# Altium schematic sheet CuC_PSU.SchDoc
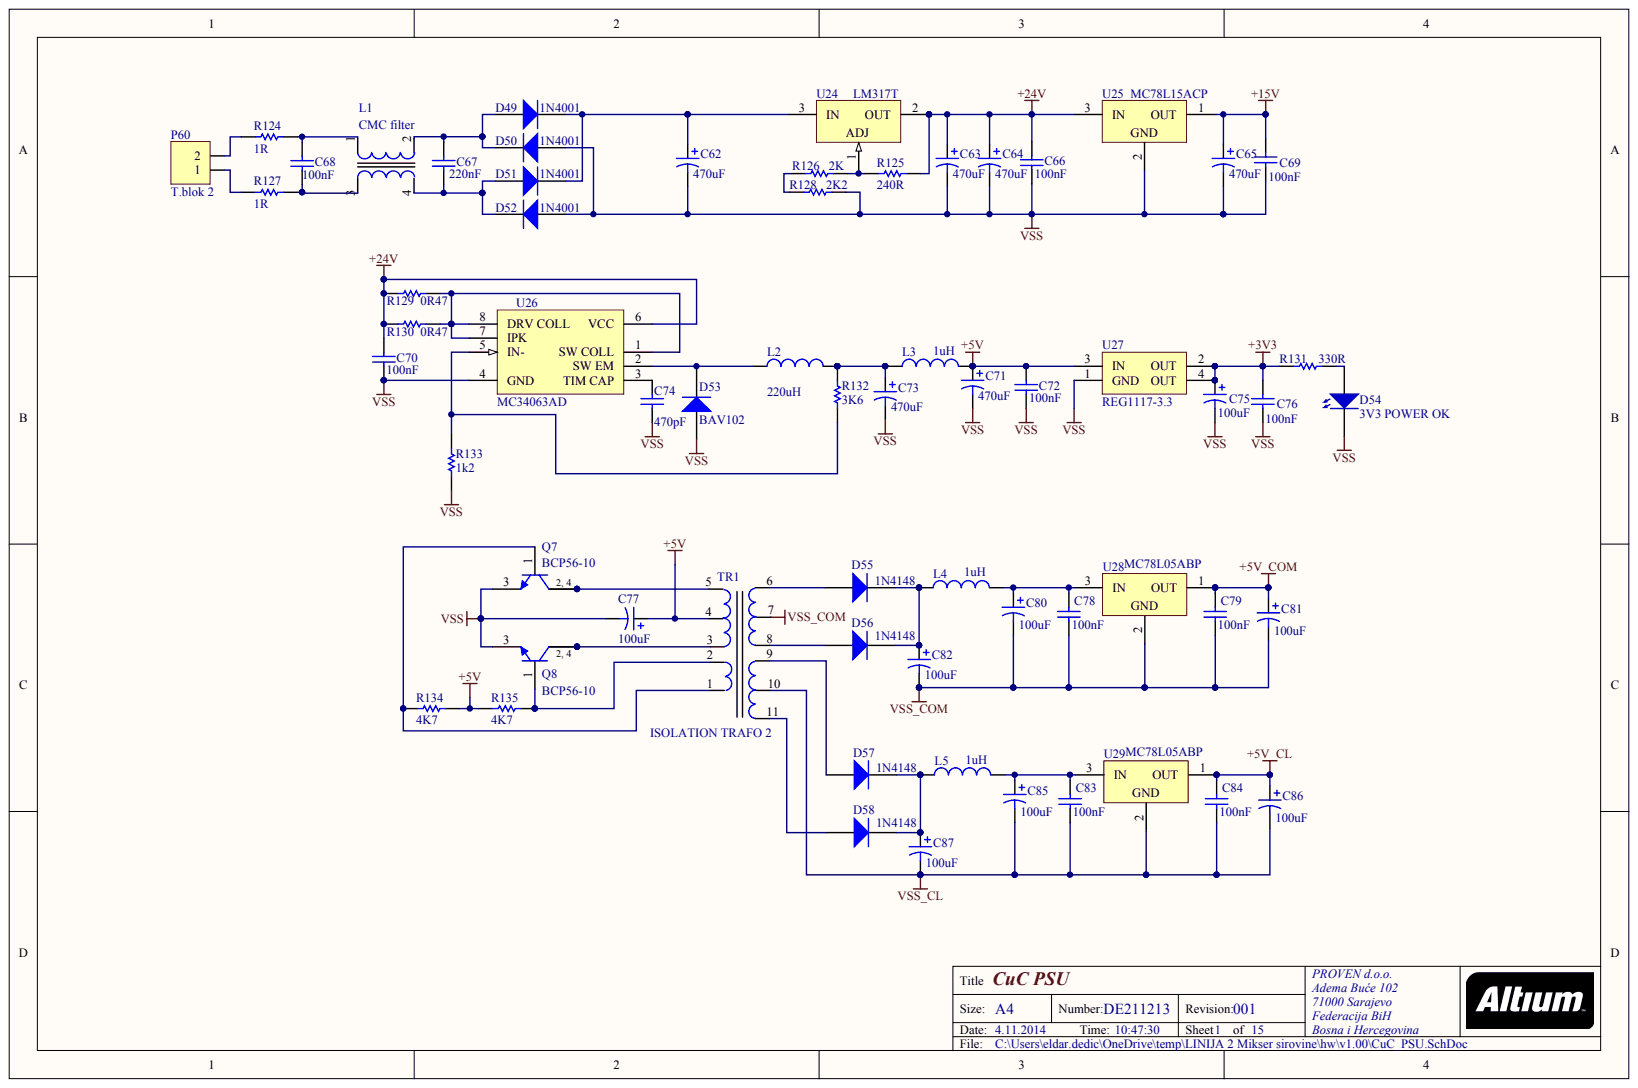
[diagram p0: CuC_PSU.SchDoc]

## Parts
C62  470uF
C63  470uF
C64  470uF
C65  470uF
C66  value 100nF
C67  220nF
C68  100nF
C69  value 100nF
C70  value 100nF
C71  470uF
C72  value 100nF
C73  470uF
C74  value 470pF
C75  100uF
C76  value 100nF
C77  100uF
C78  value 100nF
C79  value 100nF
C80  100uF
C81  100uF
C82  100uF
C83  value 100nF
C84  value 100nF
C85  100uF
C86  100uF
C87  100uF
D49  1N4001
D50  1N4001
D51  1N4001
D52  1N4001
D53  BAV102
D54  3V3 POWER OK
D55  1N4148
D56  1N4148
D57  1N4148
D58  1N4148
L1  CMC filter
L2  value 220uH
L3  value 1uH
L4  value 1uH
L5  value 1uH
P60  T.blok 2
Q7  BCP56-10
Q8  BCP56-10
R124  1R
R125  240R
R126  2K
R127  1R
R128  2K2
R129  0R47
R130  0R47
R131  330R
R132  3K6
R133  1k2
R134  4K7
R135  4K7
TR1  ISOLATION TRAFO 2
U24  LM317T
U25  MC78L15ACP
U26  MC34063AD
U27  REG1117-3.3
U28  MC78L05ABP
U29  MC78L05ABP

## Nets
+3V3: C75.1 C76.2 R131.1 U27.2(OUT) U27.4(OUT)
+5V: C71.1 C72.2 C77.1 L3.2 R134.1 R135.2 TR1.4 U27.3(IN)
+5V_CL: C84.2 C86.1 U29.1
+5V_COM: C79.2 C81.1 U28.1
+15V: C65.1 C69.2 U25.1
+24V: C63.1 C64.1 C66.2 C70.2 R125.1 R129.1 R130.1 U24.2 U25.3 U26.6(VCC)
VSS: C62.2 C63.2 C64.2 C65.2 C66.1 C69.1 C70.1 C71.2 C72.1 C73.2 C74.1 C75.2 C76.1 C77.2 D50.1 D52.1 D53.1 D54.1 Q7.3 Q8.3 R128.1 R133.1 U25.2 U26.4(GND) U27.1(GND)
VSS_CL: C83.1 C84.1 C85.2 C86.2 C87.2 TR1.10 U29.2
VSS_COM: C78.1 C79.1 C80.2 C81.2 C82.2 TR1.7 U28.2
NetC62_1: C62.1 D49.2 D51.2 U24.3
NetC67_1: C67.1 D51.1 D52.2 L1.4
NetC67_2: C67.2 D49.1 D50.2 L1.2
NetC68_1: C68.1 L1.3 R127.1
NetC68_2: C68.2 L1.1 R124.1
NetC73_1: C73.1 L2.2 L3.1 R132.2
NetC74_2: C74.2 U26.3(TIM_CAP)
NetC78_2: C78.2 C80.1 L4.2 U28.3
NetC82_1: C82.1 D55.2 D56.2 L4.1
NetC83_2: C83.2 C85.1 L5.2 U29.3
NetC87_1: C87.1 D57.2 D58.2 L5.1
NetD53_2: D53.2 L2.1 U26.2(SW_EM)
NetD54_2: D54.2 R131.2
NetD55_1: D55.1 TR1.6
NetD56_1: D56.1 TR1.8
NetD57_1: D57.1 TR1.9
NetD58_1: D58.1 TR1.11
NetP60_1: P60.1 R127.2
NetP60_2: P60.2 R124.2
NetQ7_1: Q7.1 R134.2 TR1.1
NetQ7_2: Q7.2 Q7.4 TR1.5
NetQ8_1: Q8.1 R135.1 TR1.2
NetQ8_2: Q8.2 Q8.4 TR1.3
NetR125_2: R125.2 R126.2 U24.1
NetR126_1: R126.1 R128.2
NetR129_2: R129.2 R130.2 U26.1(SW_COLL) U26.7(IPK) U26.8(DRV_COLL)
NetR132_1: R132.1 R133.2 U26.5(IN-)

## Library data of the parts
C62-C65 C71 C73 C75 C77 C80-C82 C85-C87 = (no symbol name): Note: Refer to Manufacturer's Data Sheets for other lead formations; DrillSize: Nominal, Rounded To The Nearest 0.1mm; Published: 19-Mar-2004; DatasheetDocument: 20-Feb-2001; ComponentLink1Description: Manufacturer Link; ComponentLink1URL: http://www.vishay.com/; ComponentLink2Description: Datasheet; ComponentLink2URL: http://www.vishay.com/docs/25014/eka.pdf; Publisher: Altium Limited
  C62-C65 C71 C73: PackageReference: CAPPR5-10X16; Value: 470uF
  C75 C77 C80-C82 C85-C87: PackageReference: CAPPR2-5X11; Value: 100uF
C67 C68 = (no symbol name): Value: 68nF; Published: 17-Dec-2003; LatestRevisionDate: 19-Mar-2004; LatestRevisionNote: PCB Footprint - Drill hole diameter reduced.; DatasheetDocument: 2-Jan-2002; PackageDocument: 2-Jan-2002; PackageReference: VP60-3.6; ComponentLink1Description: Manufacturer Link; ComponentLink1URL: http://www.vishay.com/; Publisher: Altium Limited
C74 = (no symbol name): Note: Footprint corresponds to dimensions for bulk cassette packaging. This case size has tightened tolerances.; Published: 17-Jul-2002; LatestRevisionDate: 19-Mar-2004; LatestRevisionNote: Silkscreen size reduced.; DatasheetDocument: Mar-2001; PackageDocument: Mar-2001; PackageReference: 0805; Code_JEITA: 0805; Code_IPC: 2012[0805]; ComponentLink1Description: Manufacturer Link; ComponentLink1URL: http://www.kemet.com/; ComponentLink2Description: Datasheet; ComponentLink2URL: http://www.kemet.com/kemet/web/homepage/kechome.nsf/vapubfilesname/F3102TA.pdf/$file/F3102TA.pdf; Publisher: Altium Limited
L2-L5 = (no symbol name): PCB_Layout: Complies with manufacturer's recommendation.; Published: 26-Sep-2008; DatasheetVersion: Oct-2007; PackageVersion: Oct-2007; PackageReference: PCMC063T; PackageDescription: SM Power Choke Coil; 2 Leads; Body 7.3 x 6.6 x 3.0 mm (LxWxH); ComponentLink1Description: Manufacturer Link; ComponentLink1URL: http://www.susumu.co.jp/; ComponentLink2Description: Datasheet; ComponentLink2URL: http://www.susumu.co.jp/english/pdf/products-j07-01.pdf; Publisher: Altium Limited
R125 R126 R128 R131-R135 = (no symbol name): Note: If flow soldering, adjust pad width of PCB Footprint to 0.7 - 0.8 times the width of the resistor.; Published: 17-Dec-2003; LatestRevisionDate: 19-Mar-2004; LatestRevisionNote: Silkscreen size reduced.; PackageDocument: Mar-2003; ComponentLink1Description: Manufacturer Link; ComponentLink1URL: http://www.panasonic.com/industrial/components/resistive_products.htm; ComponentLink2Description: Datasheet; Publisher: Altium Limited
  R125 R126 R128 R132-R135: PackageReference: ERA-0805; Code_IPC: 2012 [0805]; ComponentLink2URL: http://www.panasonic.com/industrial/components/pdf/AOF0000CE1.pdf
  R131: PackageReference: ERA-0603; Code_IPC: 1608 [0603]; ComponentLink2URL: http://www.panasonic.com/industrial/components/pdf/AOA0000CE8.pdf
U24 = (no symbol name): Note: Heatsink surface connected to pin 2.; Published: 17-Jul-2002; DatasheetVersion: 1988; PackageReference: 221A-04; PackageDescription: TO, Thru-Hole, Vertical, Heatsink Mounted; 3 In-Line Leads; Pitch 2.54 mm; Code_JEDEC: TO-262-AA; ComponentLink1Description: Manufacturer Link; ComponentLink1URL: http://www.onsemi.com/site/products/taxonomy/0,4438,503,00.html; ComponentLink2Description: Datasheet; ComponentLink2URL: http://www.onsemi.com/pub/Collateral/LM317-D.PDF; Publisher: Altium Limited
C66 C69 C70 C72 C76 C78 C79 C83 C84 D49-D58 L1 P60 Q7 Q8 R124 R127 R129 R130 TR1 U25-U29 = (no symbol name)
  C66 C69 C70 C72 C76 C78 C79 C83 C84: Published: 17-Jul-2002; LatestRevisionDate: 19-Mar-2004; LatestRevisionNote: Silkscreen size reduced.; DatasheetDocument: Mar-2001; PackageDocument: Mar-2001; PackageReference: 1206; Code_JEITA: 1206; Code_IPC: 3216 [1206]; ComponentLink1Description: Manufacturer Link; ComponentLink1URL: http://www.kemet.com/; ComponentLink2Description: Datasheet; ComponentLink2URL: http://www.kemet.com/kemet/web/homepage/kechome.nsf/vapubfilesname/F3102TA.pdf/$file/F3102TA.pdf
  D49-D52: Published: 8-Jun-2000; LatestRevisionDate: 17-Jul-2002; LatestRevisionNote: Re-released for DXP Platform.; DatasheetVersion: 1998; PackageVersion: Aug-1999; PackageReference: DO-41; PackageDescription: Diode, Axial; Body 5.2 x 2.7 mm (LxDia. max), Lead Dia. 0.86 mm (max); Code_JEDEC: DO-204-AL; ComponentLink1Description: Manufacturer Link; ComponentLink1URL: http://www.fairchildsemi.com/; ComponentLink2Description: Datasheet; ComponentLink2URL: http://www.fairchildsemi.com/ds/1N%2F1N4001.pdf
  D53: Published: 17-Sep-2003; LatestRevisionDate: 19-Mar-2004; LatestRevisionNote: Silkscreen size reduced.; DatasheetVersion: 17-Sep-1996; PackageVersion: Jan-2002; PackageReference: SOD80C; PackageDescription: Metal Electrode Face (MELF) Diode; Body 3.5 x 1.6 mm (LxDia); ComponentLink1Description: Manufacturer Link; ComponentLink1URL: http://www.semiconductors.philips.com/; ComponentLink2Description: Datasheet; ComponentLink2URL: http://www.semiconductors.philips.com/acrobat/datasheets/BAV100_2.pdf
  D55-D58: Published: 8-Jun-2000; LatestRevisionDate: 17-Jul-2002; LatestRevisionNote: Re-released for DXP Platform.; DatasheetVersion: 1997; PackageReference: DO-35; PackageDescription: Diode, Axial; Body 3.8 x 1.9 mm (LxDia. max), Lead Dia. 0.53 mm (max); Code_JEDEC: DO-204-AH; ComponentLink1Description: Manufacturer Link; ComponentLink1URL: http://www.fairchildsemi.com/; ComponentLink2Description: Datasheet; ComponentLink2URL: http://www.fairchildsemi.com/ds/1N%2F1N4148.pdf
  Q7 Q8: Published: 17-Sep-2003; LatestRevisionDate: 12-Dec-2006; LatestRevisionNote: IPC-7351 Footprint Added.; DatasheetVersion: 10-Oct-2001; PackageVersion: Aug-2001; PackageReference: SOT223; PackageDescription: 4-Pin SOT-223 Package 2.3 mm Pitch, 7 mm Lead Span; ComponentLink1Description: Manufacturer Link; ComponentLink1URL: http://www.semiconductors.philips.com/; ComponentLink2Description: Datasheet; ComponentLink2URL: http://www.semiconductors.philips.com/acrobat/datasheets/BC639_BCP56_BCX56_7.pdf
  R124 R127: Published: 17-Dec-2003; PackageReference: R6.35x2.3; ComponentLink1Description: Manufacturer Link; ComponentLink1URL: http://www.panasonic.com/industrial/components/resistive_products.htm; ComponentLink2Description: Datasheet; ComponentLink2URL: http://www.panasonic.com/industrial/components/pdf/AOA0000CE18.pdf
  R129 R130: Published: 17-Dec-2003; PackageReference: RD6.35x2.3; ComponentLink1Description: Manufacturer Link; ComponentLink1URL: http://www.panasonic.com/industrial/components/resistive_products.htm; ComponentLink2Description: Datasheet; ComponentLink2URL: http://www.panasonic.com/industrial/components/pdf/AOA0000CE12.pdf
  U25 U28 U29: Published: 17-Jul-2002; DatasheetVersion: 1988; PackageVersion: 1996; PackageReference: 29-04; PackageDescription: TO, Flat Index; 3 In-Line, Axial Leads; Body Dia. 4.8mm; Leads 0.48 x 0.5 mm (max); ComponentLink1Description: Manufacturer Link; ComponentLink1URL: http://www.onsemi.com/site/products/taxonomy/0,4438,503,00.html; ComponentLink2Description: Datasheet; ComponentLink2URL: http://www.onsemi.com/pub/Collateral/MC78L00A-D.PDF
  U26: Published: 15-Apr-2002; LatestRevisionDate: 13-Apr-2006; LatestRevisionNote: IPC-7351 Footprint Added.; DatasheetVersion: 1996; PackageVersion: 1998; PackageReference: 751-05; PackageDescription: 8-Pin Small Outline Integrated Circuit 1.27 mm Pitch; Code_JEDEC: MS-012-AA; Code_IPC: SOIC127P600-8; ComponentLink1Description: Manufacturer Link; ComponentLink1URL: http://www.onsemi.com/site/products/taxonomy/0,4438,503,00.html; ComponentLink2Description: Datasheet; ComponentLink2URL: http://www.onsemi.com/pub/Collateral/MC34063A-D.PDF
  U27: Published: 8-Jun-2000; LatestRevisionDate: 17-Jul-2002; LatestRevisionNote: Re-released for DXP Platform.; DatasheetVersion: Sep-1997; PackageVersion: revC; PackageReference: ZZ311; PackageDescription: SOT-223/SC-73; 4 Leads; Body 6.7 x 7.3 mm, inc. leads (LxW max); ComponentLink1Description: Manufacturer Link; ComponentLink1URL: http://www.ti.com/; ComponentLink2Description: Datasheet; ComponentLink2URL: http://www-s.ti.com/sc/ds/REG1117.pdf
  C66 C69 C70 C72 C76 C78 C79 C83 C84 D49-D53 D55-D58 Q7 Q8 R124 R127 R129 R130 U25-U29: Publisher: Altium Limited
